# Altium schematic sheet Sheet1.SchDoc
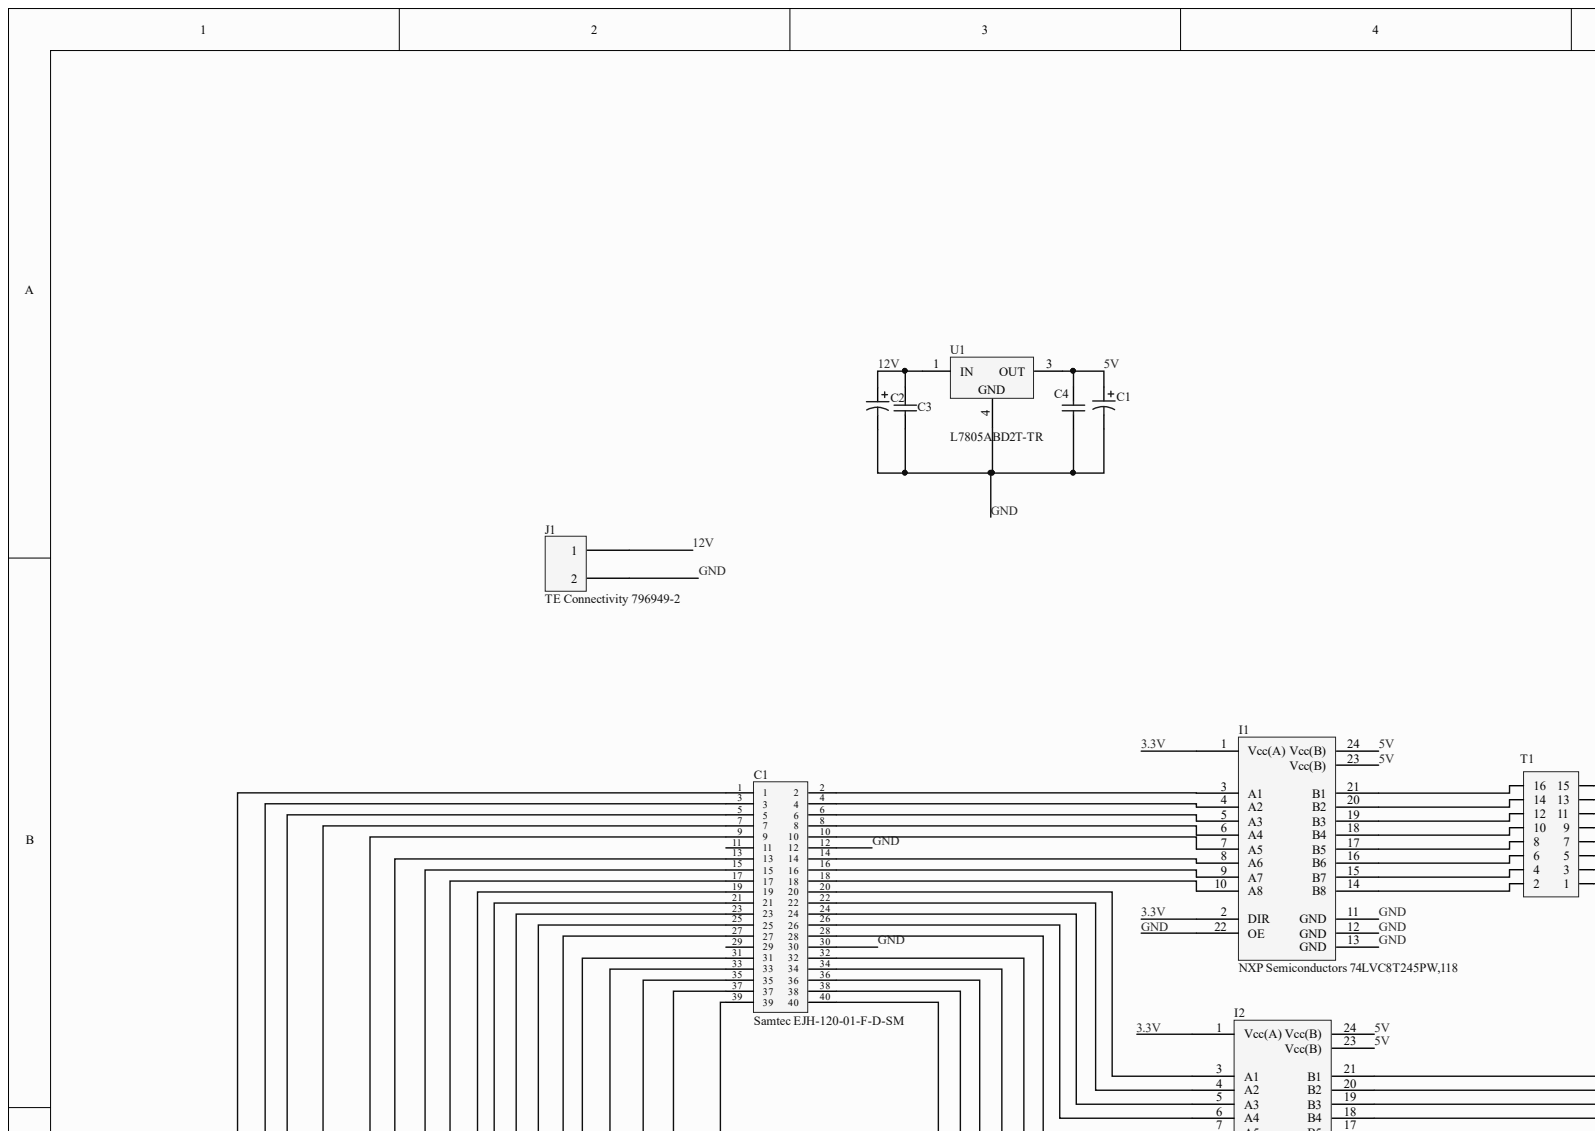
[diagram p0: Sheet1.SchDoc, top left]
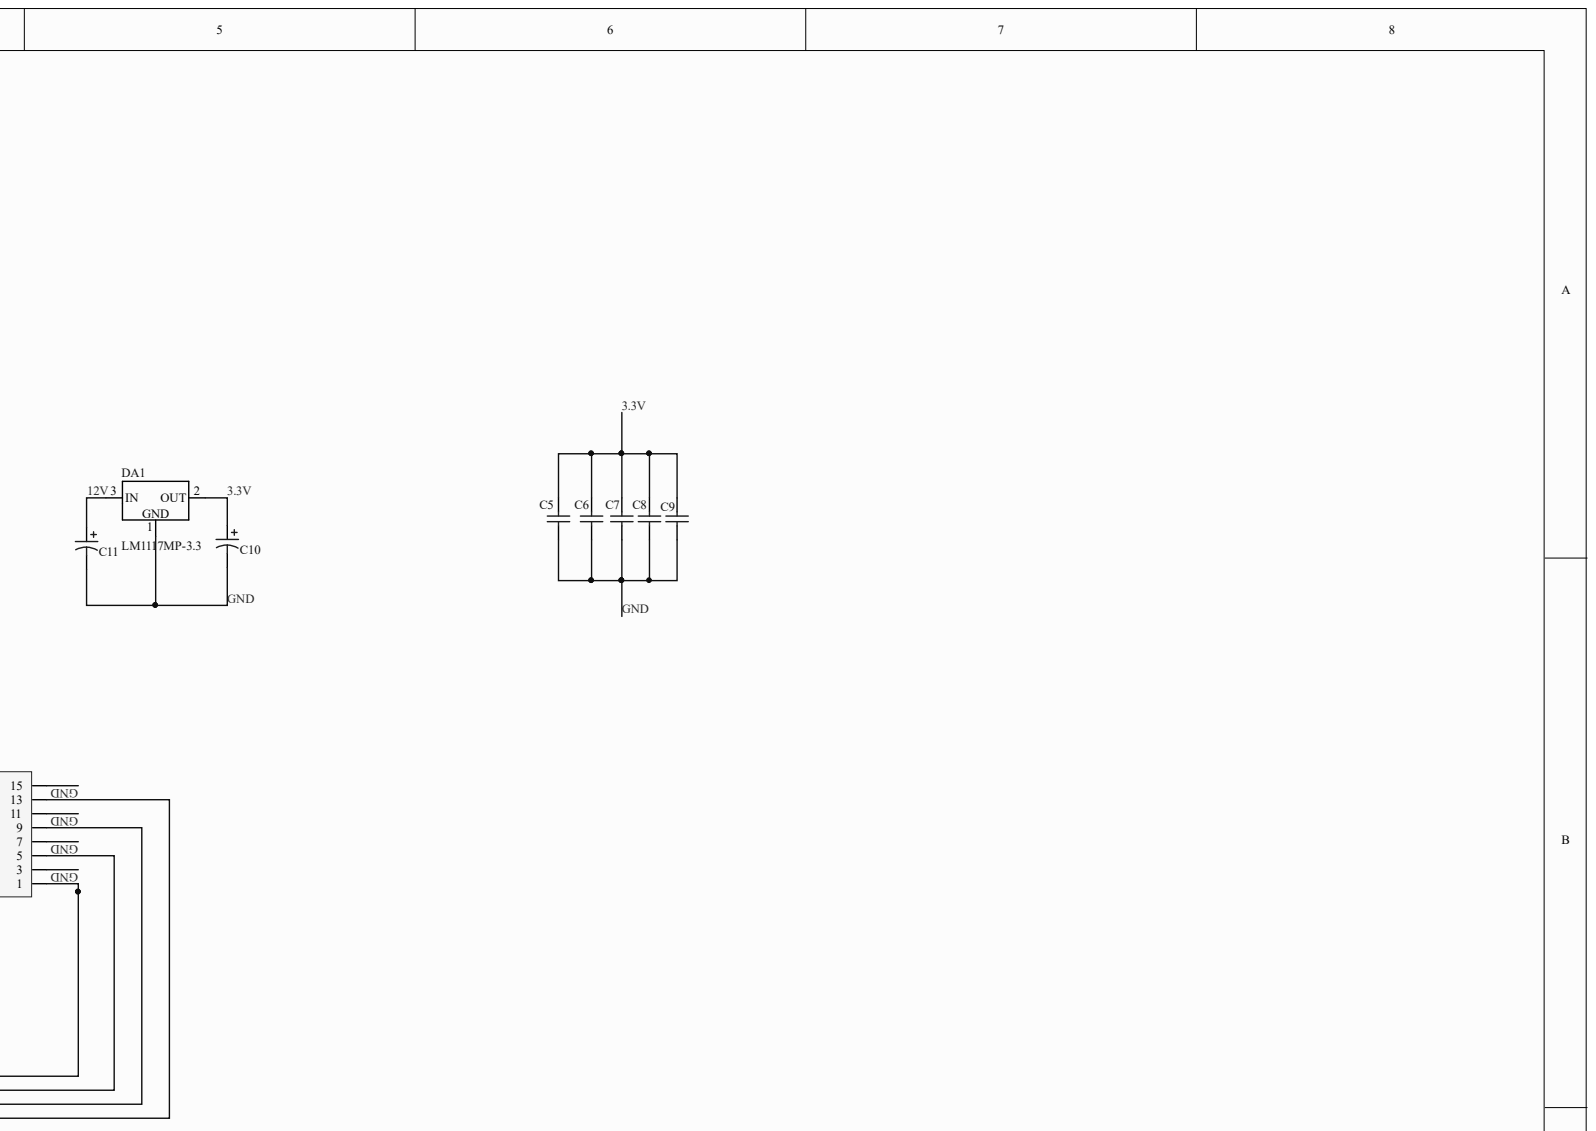
[diagram p1: Sheet1.SchDoc, top right]
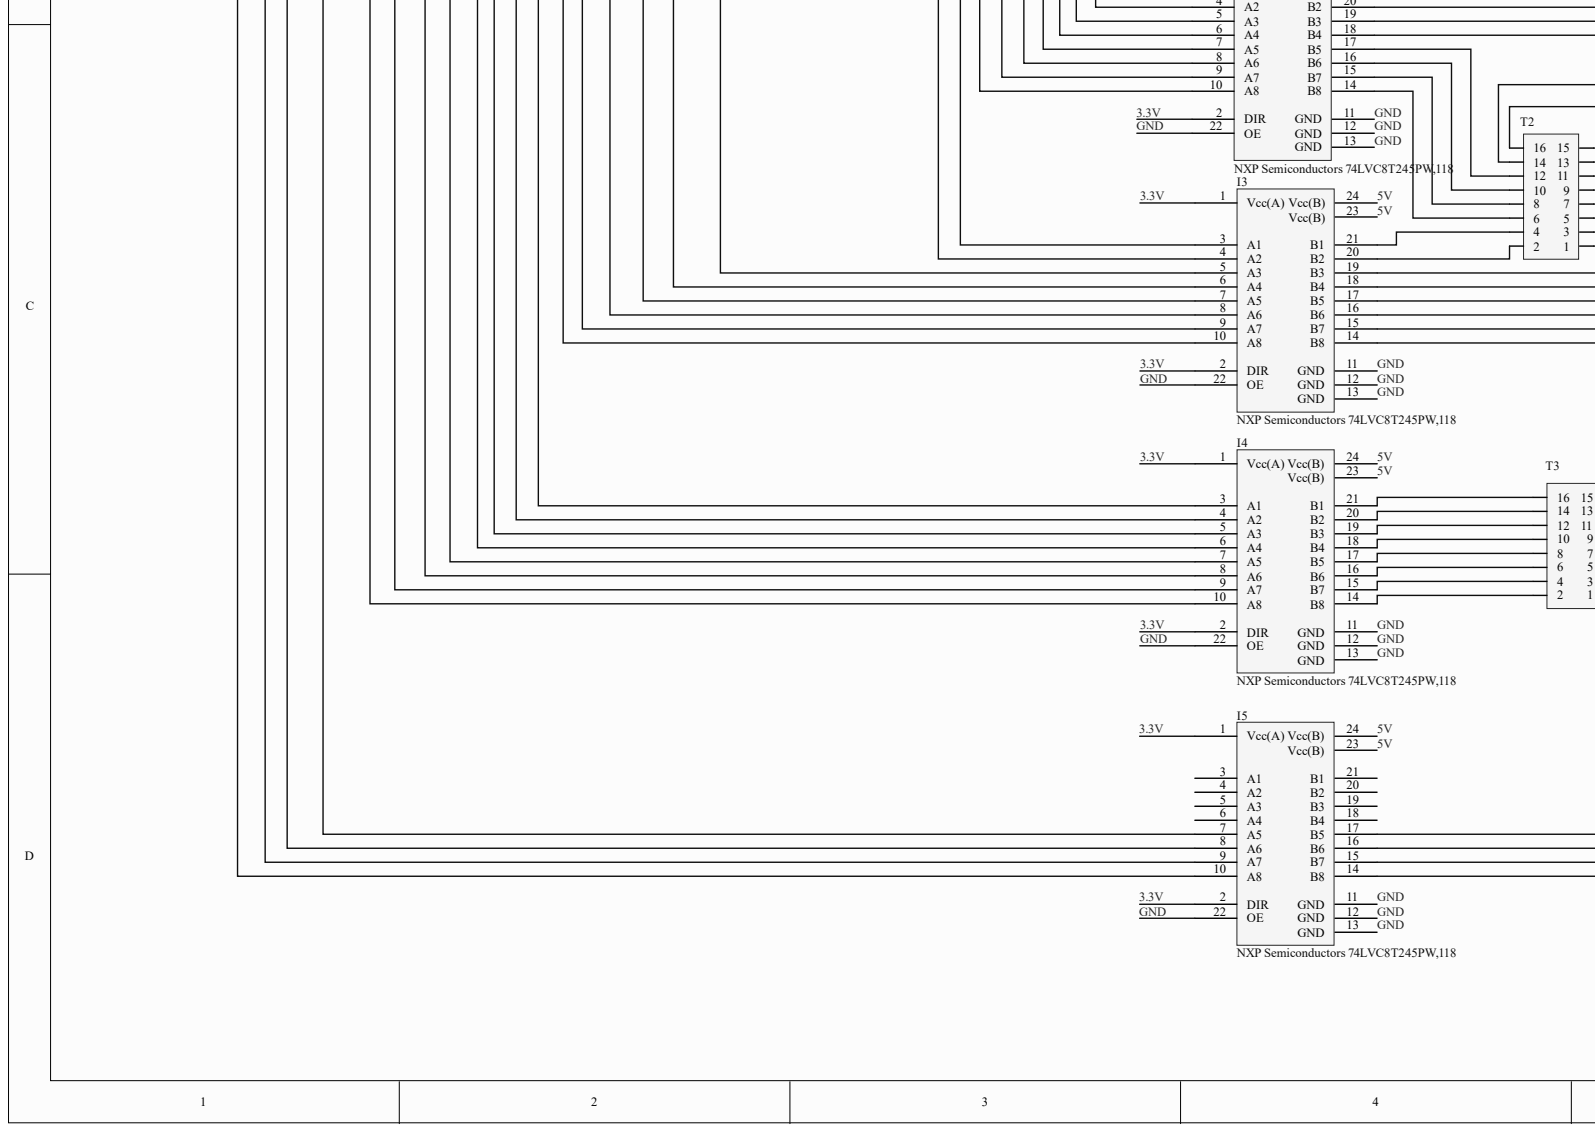
[diagram p2: Sheet1.SchDoc, bottom left]
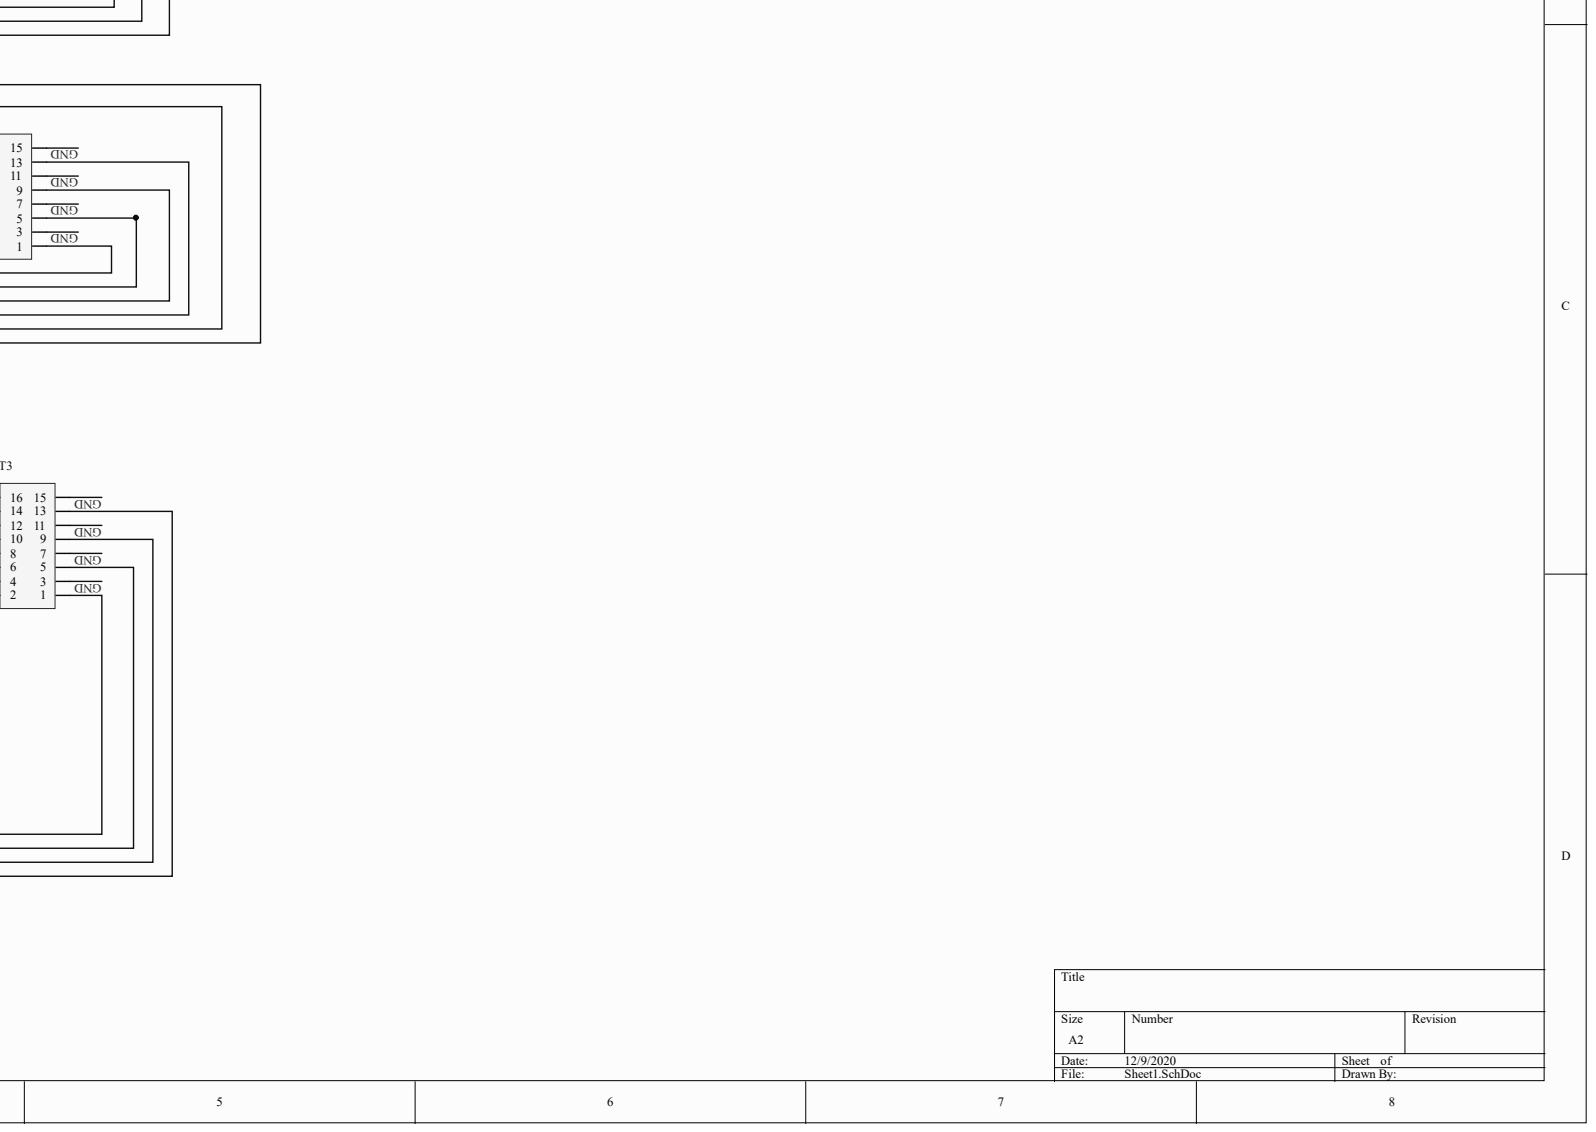
[diagram p3: Sheet1.SchDoc, bottom right]

## Parts
C1  47µF  [ELCO-6.3-12-2.5]  (value not drawn)
C1  Samtec EJH-120-01-F-D-SM  Manufacturer Part Number: EJH-120-01-F-D-SM  [PCB-50kh87ngw7473td6odol-1]
C2  Panasonic EEU-FC1V471  [EEUFC1V471]  (value not drawn)
C3  AVX Interconnect / Elco 08053C334KAZ2A  [CAPC2013X140X50ML20T25]  (value not drawn)
C4  AVX Interconnect / Elco 08051C104M4Z2A  [CAPC2013X140X50ML20T25]  (value not drawn)
C5  Kemet C0805C475K8PACTU  [C0805K]  (value not drawn)
C6  Kemet C0805C475K8PACTU  [C0805K]  (value not drawn)
C7  Kemet C0805C475K8PACTU  [C0805K]  (value not drawn)
C8  Kemet C0805C475K8PACTU  [C0805K]  (value not drawn)
C9  Kemet C0805C475K8PACTU  [C0805K]  (value not drawn)
C10  Cap Pol2  [Capacitor]  (value not drawn)
C11  Cap Pol2  [Capacitor]  (value not drawn)
DA1  LM1117MP-3.3  [MP04A_L]
I1  NXP Semiconductors 74LVC8T245PW,118  Manufacturer Part Number: 74LVC8T245PW,118  [PCB-rw87e6j2zae54fwd3y82-1]
I2  NXP Semiconductors 74LVC8T245PW,118  Manufacturer Part Number: 74LVC8T245PW,118  [PCB-rw87e6j2zae54fwd3y82-1]
I3  NXP Semiconductors 74LVC8T245PW,118  Manufacturer Part Number: 74LVC8T245PW,118  [PCB-rw87e6j2zae54fwd3y82-1]
I4  NXP Semiconductors 74LVC8T245PW,118  Manufacturer Part Number: 74LVC8T245PW,118  [PCB-rw87e6j2zae54fwd3y82-1]
I5  NXP Semiconductors 74LVC8T245PW,118  Manufacturer Part Number: 74LVC8T245PW,118  [PCB-rw87e6j2zae54fwd3y82-1]
J1  TE Connectivity 796949-2  [PCB-genlv6ka4twigaugn851-1]
T1  TE Connectivity / AMP 5103308-3  [PCB-ez7c9rqqhu21cqzcwvs2-1]  (value not drawn)
T2  TE Connectivity / AMP 5103308-3  [PCB-ez7c9rqqhu21cqzcwvs2-1]  (value not drawn)
T3  TE Connectivity / AMP 5103308-3  [PCB-ez7c9rqqhu21cqzcwvs2-1]  (value not drawn)
U1  L7805ABD2T-TR  [TO-263_N]

## Nets
3.3V: C5.1 C6.1 C7.1 C8.1 C9.1 C10.1 DA1.2 I1.1(Vcc(A)) I1.2(DIR) I2.1(Vcc(A)) I2.2(DIR) I3.1(Vcc(A)) I3.2(DIR) I4.1(Vcc(A)) I4.2(DIR) I5.1(Vcc(A)) I5.2(DIR)
5V: C1.1 C4.2 I1.23(Vcc(B)) I1.24(Vcc(B)) I2.23(Vcc(B)) I2.24(Vcc(B)) I3.23(Vcc(B)) I3.24(Vcc(B)) I4.23(Vcc(B)) I4.24(Vcc(B)) I5.23(Vcc(B)) I5.24(Vcc(B)) U1.3
12V: C2.1 C3.2 C11.1 DA1.3 J1.1 U1.1
GND: C1.2 C1.12 C1.30 C2.2 C3.1 C4.1 C5.2 C6.2 C7.2 C8.2 C9.2 C10.2 C11.2 DA1.1 I1.11(GND) I1.12(GND) I1.13(GND) I1.22(OE) I2.11(GND) I2.12(GND) I2.13(GND) I2.22(OE) I3.11(GND) I3.12(GND) I3.13(GND) I3.22(OE) I4.11(GND) I4.12(GND) I4.13(GND) I4.22(OE) I5.11(GND) I5.12(GND) I5.13(GND) I5.22(OE) J1.2 T1.3 T1.7 T1.11 T1.15 T2.3 T2.7 T2.11 T2.15 T3.3 T3.7 T3.11 T3.15 U1.4
NetC1_1: C1.1 I5.10(A8)
NetC1_2: C1.2 I1.3(A1)
NetC1_3: C1.3 I5.9(A7)
NetC1_4: C1.4 I1.4(A2)
NetC1_5: C1.5 I5.8(A6)
NetC1_6: C1.6 I1.5(A3)
NetC1_7: C1.7 I5.7(A5)
NetC1_8: C1.8 I1.6(A4)
NetC1_9: C1.9 I4.10(A8)
NetC1_10: C1.10 I1.7(A5)
NetC1_13: C1.13 I4.9(A7)
NetC1_14: C1.14 I1.8(A6)
NetC1_15: C1.15 I4.8(A6)
NetC1_16: C1.16 I1.9(A7)
NetC1_17: C1.17 I4.7(A5)
NetC1_18: C1.18 I1.10(A8)
NetC1_19: C1.19 I4.6(A4)
NetC1_20: C1.20 I2.3(A1)
NetC1_21: C1.21 I4.5(A3)
NetC1_22: C1.22 I2.4(A2)
NetC1_23: C1.23 I4.4(A2)
NetC1_24: C1.24 I2.5(A3)
NetC1_25: C1.25 I4.3(A1)
NetC1_26: C1.26 I2.6(A4)
NetC1_27: C1.27 I3.10(A8)
NetC1_28: C1.28 I2.7(A5)
NetC1_31: C1.31 I3.9(A7)
NetC1_32: C1.32 I2.8(A6)
NetC1_33: C1.33 I3.8(A6)
NetC1_34: C1.34 I2.9(A7)
NetC1_35: C1.35 I3.7(A5)
NetC1_36: C1.36 I2.10(A8)
NetC1_37: C1.37 I3.6(A4)
NetC1_38: C1.38 I3.3(A1)
NetC1_39: C1.39 I3.5(A3)
NetC1_40: C1.40 I3.4(A2)
NetI1_14: I1.14(B8) T1.2
NetI1_15: I1.15(B7) T1.4
NetI1_16: I1.16(B6) T1.6
NetI1_17: I1.17(B5) T1.8
NetI1_18: I1.18(B4) T1.10
NetI1_19: I1.19(B3) T1.12
NetI1_20: I1.20(B2) T1.14
NetI1_21: I1.21(B1) T1.16
NetI2_14: I2.14(B8) T2.6
NetI2_15: I2.15(B7) T2.8
NetI2_16: I2.16(B6) T2.10
NetI2_17: I2.17(B5) T2.12
NetI2_18: I2.18(B4) T1.13
NetI2_19: I2.19(B3) T1.9
NetI2_20: I2.20(B2) T1.5
NetI2_21: I2.21(B1) T1.1
NetI3_14: I3.14(B8) T2.14
NetI3_15: I3.15(B7) T2.16
NetI3_16: I3.16(B6) T2.13
NetI3_17: I3.17(B5) T2.9
NetI3_18: I3.18(B4) T2.5
NetI3_19: I3.19(B3) T2.1
NetI3_20: I3.20(B2) T2.2
NetI3_21: I3.21(B1) T2.4
NetI4_14: I4.14(B8) T3.2
NetI4_15: I4.15(B7) T3.4
NetI4_16: I4.16(B6) T3.6
NetI4_17: I4.17(B5) T3.8
NetI4_18: I4.18(B4) T3.10
NetI4_19: I4.19(B3) T3.12
NetI4_20: I4.20(B2) T3.14
NetI4_21: I4.21(B1) T3.16
NetI5_14: I5.14(B8) T3.13
NetI5_15: I5.15(B7) T3.9
NetI5_16: I5.16(B6) T3.5
NetI5_17: I5.17(B5) T3.1

Not connected: C1 11 29; I5 3(A1) 4(A2) 5(A3) 6(A4) 18(B4) 19(B3) 20(B2) 21(B1)

## Library data of the parts
C1 = CMP-5ae993e06a1d852e-2: 47uF 63 V dc Aluminium Electrolytic Capacitor, VR Series 2000h 6.3 (Dia.) x 11mm; Lead-Free Status: Lead Free; Voltage Rating (DC): 63.0 V; Component Kind: Standard; Library Name: CommunityVault; Mounting Style: Through Hole; Number of Pins: 2; Octopart URL: http://octopart.com/uvr1j470med-nichicon-202130; Packaging: Bulk; Simulation: SIM-p7yvtmo9oy586ghypkfe-1; Size-Diameter: 6.30 mm; Size-Length: 11.0 mm
C1 = CMP-4c5b85e18c97939c-1: Conn Ejector Header HDR 40 POS 2.54mm Solder ST SMD Tube; Gender: Male; Number of Contacts: 40; Component Kind: Standard; Contact Material: Phosphor Bronze; Contact Plating: Gold; Library Name: FavoritesLibrary; Mounting Style: Surface Mount, Vertical; OctopartID: 4c5b85e18c97939c; Octopart URL: https://octopart.com/ejh-120-01-f-d-sm-samtec-11593130; REACH SVHC Compliance: No SVHC; RoHS: Compliant
C2 = CMP-1dacd6fc5c36c26b-2: Cap Aluminum Lytic 470uF 35V 20% (10 X 20mm) Radial 5mm 1220mA 3000h 105Ø¢°C Automotive Bulk; Capacitance: 470.0 F; Dielectric Material: Aluminum Electrolytic; Lead-Free Status: Lead Free; Voltage Rating (DC): 35.0 V; Capacitance Tolerance: ±20%; Component Kind: Standard; Library Name: CommunityVault; Lifecycle Status: Not Listed by Manufacturer; Manufacturer Part Number: EEU-FC1V471; Mounting Style: Through Hole; Number of Pins: 2; OctopartID: 1dacd6fc5c36c26b; Octopart URL: http://octopart.com/eeu-fc1v471-panasonic-39478524; Packaging: Bulk; REACH SVHC Compliance: No SVHC; RoHS: Compliant; Size-Diameter: 0.01 m; Size-Height: 0.02 m; Size-Length: 0.02 m
C3 = CMP-126679-2: CAP CER 0.33UF 25V 10% X7R 0805; Capacitance: 3.3e-07 F; Case/Package (SI): 2012; Lead-Free Status: Lead Free; Voltage Rating (DC): 25.0 V; Capacitance Tolerance: ±10%; Case/Package: 0805; Component Kind: Standard; Dielectric Characteristic: X7R; Library Name: CommunityVault; Manufacturer Part Number: 08053C334KAZ2A; Mounting Style: Surface Mount; OctopartID: 7d87b48fa4cba240; Octopart Url: https://octopart.com/08053c334kaz2a-avx+interconnect+%2F+elco-195626; RoHS: Compliant; Size-Height: 0.00094 m; Size-Length: 0.00201 m; Size-Width: 0.00125 m
C4 = CMP-4099710-3: Multilayer Ceramic Capacitors MLCC - SMD/SMT 100volts .1uF 20%; Component Kind: Standard; Library Name: CommunityVault
C5-C9 = CMP-19354402-3: CAP CER 4.7UF 10V 10% X5R 0805; Capacitance: 4.7e-06 F; Case/Package (SI): 2012; Dielectric Material: Ceramic; Lead-Free Status: Lead Free; Voltage Rating (DC): 10.0 V; Capacitance Tolerance: ±10%; Case/Package: 0805; Component Kind: Standard; Dielectric Characteristic: X5R; Library Name: CommunityVault; Manufacturer Part Number: C0805C475K8PACTU; Mounting Style: Surface Mount; Number of Pins: 2; OctopartID: 920e5b0513564dc9; Octopart Url: https://octopart.com/c0805c475k8pactu-kemet-107582; REACH SVHC Compliance: No SVHC; RoHS: Compliant; Size-Height: 0.00125 m; Size-Length: 0.002 m; Size-Width: 0.00125 m
C10 C11 = CMP-2e19e5496191f1de-3: Electrolytic capacitor Radial lead 2 mm 10 µF 50 V 20 % (Ø) 5 mm; Manufacturer Part Number: ECE-A1HKA100; Capacitance: 10.0 µF; Lead-Free Status: Lead Free; Component Kind: Standard; Library Name: CommunityVault; Mounting Style: Through Hole; OctopartID: 2e19e5496191f1de; RoHS: Compliant; Size-Diameter: 5.00 mm; Size-Height: 7.00 mm
DA1 = CMP-0062-01658-9: 800mA Low-Dropout Linear Regulator, 4-pin SOT-223; Dropout Voltage: 1.2 V; Number of Outputs: 1; Output Current: 0.8 A; Output Voltage: 3.3 V; Quiescent Current: 0.005 A; Case/Package: SOT-223; Component Kind: Standard; Library Name: CommunityVault; Lifecycle Status: Active; Manufacturer Part Number: LM1117MP-3.3; Number of Pins: 4; OctopartID: 49e78197f7209620; Octopart Url: https://octopart.com/lm1117mp-3.3-texas+instruments-24812449; Packaging: Reel; REACH SVHC Compliance: No SVHC; RoHS: Compliant
I1-I5 = CMP-b0bc789d59a86f7b-1: 74LVC Series 5.5 V 3-State 8 Bit Dual Supply Translating Transceiver - TSSOP-24; Lead-Free Status: Lead Free; Component Kind: Standard; Library Name: CommunityVault; Lifecycle Status: Active; Number of Pins: 24; OctopartID: b0bc789d59a86f7b; Octopart URL: http://octopart.com/74lvc8t245pw%2C118-nxp+semiconductors-19647602; Packaging: Cut Tape (CT); REACH SVHC Compliance: No SVHC; RoHS: Compliant; Supply Voltage (DC): V
J1 = CMP-cbef00bfe96a1428-6: Connector Terminal Blocks 2 Position 5.08mm Solder Straight Thru-Hole 17.5A/Contact; Component Kind: Standard; Library Name: CommunityVault
T1-T3 = CMP-697ba22bb0a4b6bc-2: Wire-To-Board Connector, AMP-LATCH Series, Through Hole, Header, 16, 2.54 mm, Gold Plated Contacts; Color: Black; Pin Pitch: 2.54 mm; Component Kind: Standard; Contact Plating: Gold Flash, Tin over Nickel, Tin; Housing Color: Black; Housing Material: Thermoplastic; Library Name: CommunityVault; Mounting Style: Through Hole, Vertical; Octopart URL: http://octopart.com/5103308-3-te+connectivity+%2F+amp-39517369; Size-Height: 9.78 mm
U1 = CMP-1170709-3: Positive Voltage Regulator, 3-Pin D2PAK, Tape and Reel; Dropout Voltage: 2.0 V; Lead-Free Status: Lead Free; Number of Outputs: 1; Component Kind: Standard; Library Name: CommunityVault; Lifecycle Status: Active; Manufacturer Part Number: L7805ABD2T-TR; Mounting Style: Surface Mount; Number of Pins: 3; OctopartID: dcae15acf0f70369; Octopart Url: https://octopart.com/l7805abd2t-tr-stmicroelectronics-526655; REACH SVHC Compliance: No SVHC; RoHS: Compliant
